# Altium schematic sheet WaveGenerator.SchDoc(WaveGenerator)
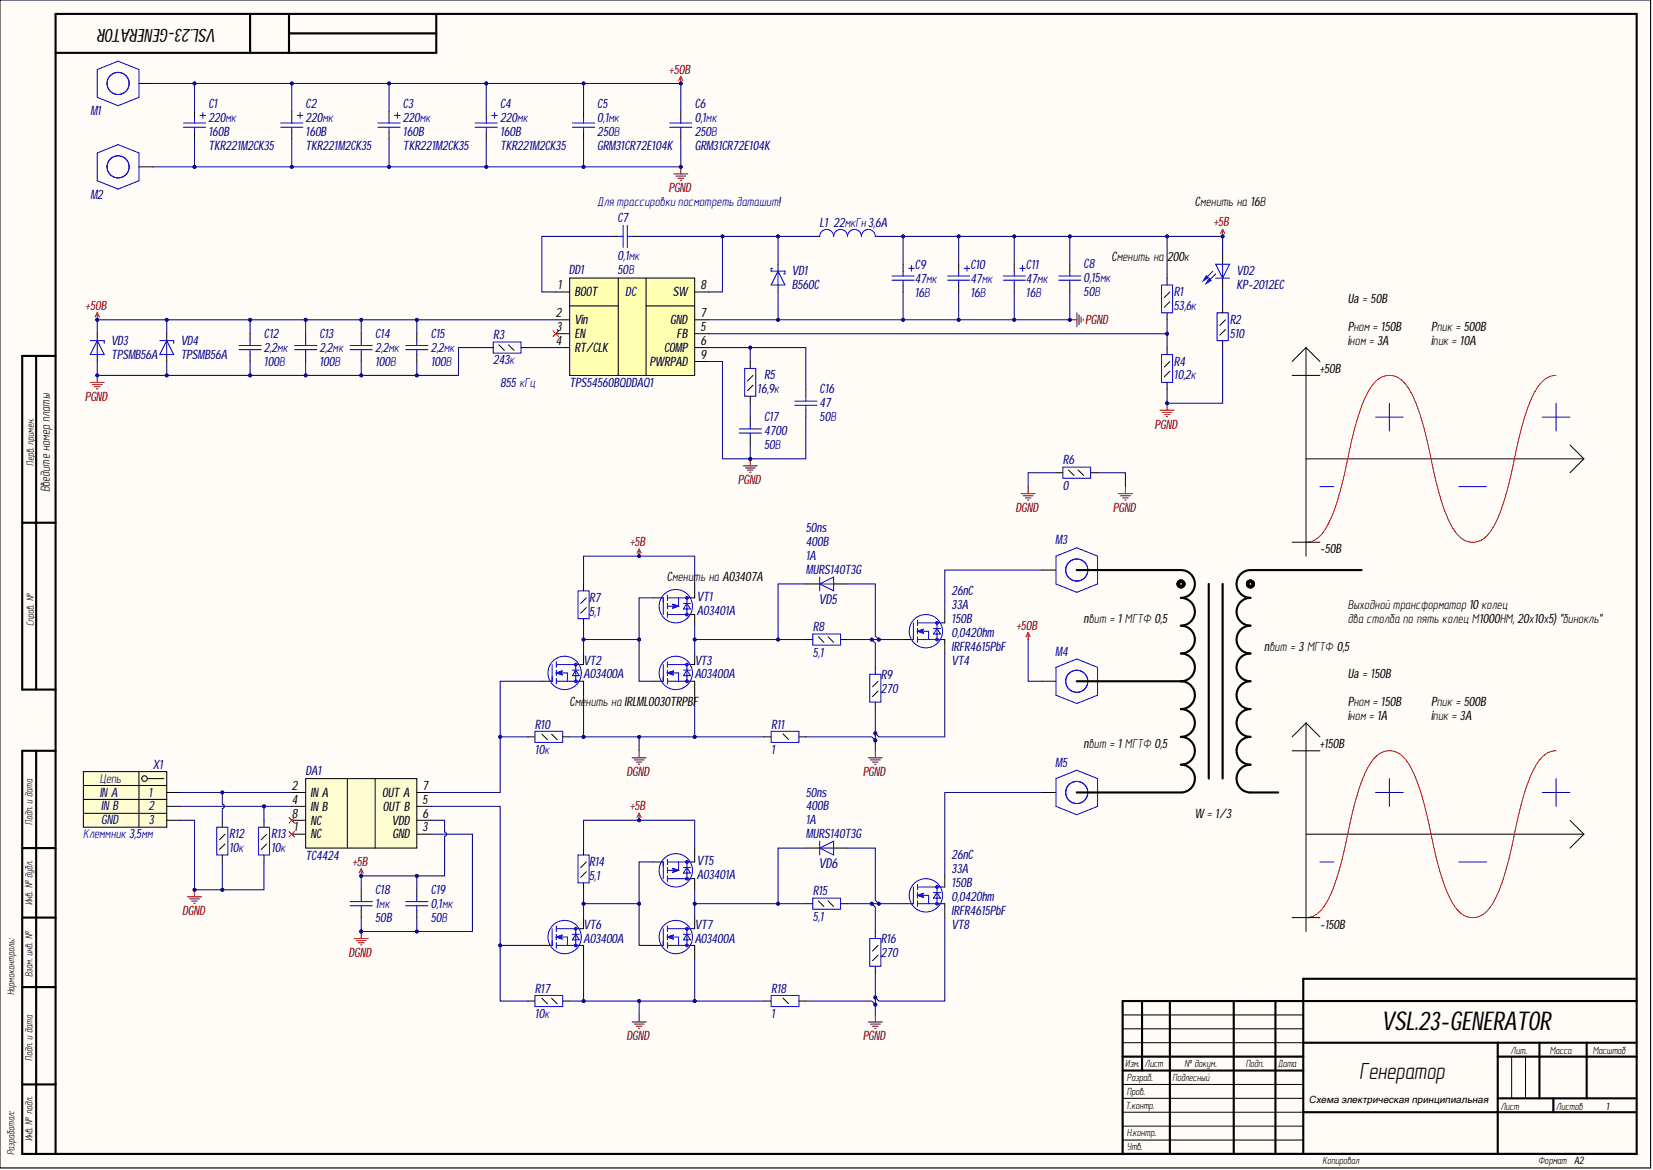
[diagram p0: WaveGenerator.SchDoc]

## Parts
C1  value 220мк  Part Number: TKR221M2CK35  Voltage: 160B  [K50-35(16X35)_V]  (hidden comment: Capacitor_pol)
C2  value 220мк  Part Number: TKR221M2CK35  Voltage: 160B  [K50-35(16X35)_V]  (hidden comment: Capacitor_pol)
C3  value 220мк  Part Number: TKR221M2CK35  Voltage: 160B  [K50-35(16X35)_V]  (hidden comment: Capacitor_pol)
C4  value 220мк  Part Number: TKR221M2CK35  Voltage: 160B  [K50-35(16X35)_V]  (hidden comment: Capacitor_pol)
C5  0,1мк  Voltage: 250В  [C1206]
C6  0,1мк  Part Number: GRM31CR72E104K  Voltage: 250В  [C1206]
C7  0,1мк  [C0402]
C8  0,15мк  Voltage: 50В  [C1812]
C9  value 47мк  Voltage: 16В  [TANTAL CASE C]  (hidden comment: Capacitor_pol)
C10  value 47мк  Voltage: 16В  [TANTAL CASE C]  (hidden comment: Capacitor_pol)
C11  value 47мк  Voltage: 16В  [TANTAL CASE C]  (hidden comment: Capacitor_pol)
C12  2,2мк  Voltage: 100В  [C1812]
C13  2,2мк  Voltage: 100В  [C1812]
C14  2,2мк  Voltage: 100В  [C1812]
C15  2,2мк  Voltage: 100В  [C1812]
C16  47  Voltage: 50В  [C0805]
C17  4700  Voltage: 50В  [C0805]
C18  1мк  Voltage: 50B  [C0805]
C19  0,1мк  Voltage: 50В  [C0805]
DA1  TC4424  [DIP8]
DD1  TPS54560  Part Number: TPS54560BQDDAQ1  [SO Powerpad8]
L1  value 22мкГн  Rated Current: 3,6A  [IND_12.5x12.5]  (hidden comment: Inductor)
M1  SCREW  [SCREW2.5]  (value not drawn)
M2  SCREW  [SCREW2.5]  (value not drawn)
M3  SCREW  [SCREW2.5]  (value not drawn)
M4  SCREW  [SCREW2.5]  (value not drawn)
M5  SCREW  [SCREW2.5]  (value not drawn)
R1  value 53,6к  [R0805]  (hidden comment: Resistor_0,125Вт)
R2  value 510  [R0805]  (hidden comment: Resistor_0,125Вт)
R3  value 243к  [R0805]  (hidden comment: Resistor_0,125Вт)
R4  value 10,2к  [R0805]  (hidden comment: Resistor_0,125Вт)
R5  value 16,9к  [R0805]  (hidden comment: Resistor_0,125Вт)
R6  value 0  [R0805]  (hidden comment: Resistor_0,125Вт)
R7  value 5,1  [R0805]  (hidden comment: Resistor_0,125Вт)
R8  value 5,1  [R0805]  (hidden comment: Resistor_0,125Вт)
R9  value 270  [R0805]  (hidden comment: Resistor_0,125Вт)
R10  value 10к  [R0805]  (hidden comment: Resistor_0,125Вт)
R11  value 1  [R1206]  (hidden comment: Resistor_0,25Вт)
R12  value 10к  [R0805]  (hidden comment: Resistor_0,125Вт)
R13  value 10к  [R0805]  (hidden comment: Resistor_0,125Вт)
R14  value 5,1  [R0805]  (hidden comment: Resistor_0,125Вт)
R15  value 5,1  [R0805]  (hidden comment: Resistor_0,125Вт)
R16  value 270  [R0805]  (hidden comment: Resistor_0,125Вт)
R17  value 10к  [R0805]  (hidden comment: Resistor_0,125Вт)
R18  value 1  [R1206]  (hidden comment: Resistor_0,25Вт)
VD1  Part Number: B560C  [SMC]  (hidden comment: DiodeSchottky)
VD2  Part Number: KP-2012EC  [L_0805]  (hidden comment: LED)
VD3  DiodeZener  [SMB]  (value not drawn)
VD4  DiodeZener  [SMB]  (value not drawn)
VD5  Forward Current: 1A  Part Number: MURS140T3G  [SMB]  (hidden comment: Diode)
VD6  Forward Current: 1A  Part Number: MURS140T3G  [SMB]  (hidden comment: Diode)
VT1  Part Number: AO3401A  [SOT23-3]  (hidden comment: P-MOSFET)
VT2  Part Number: AO3400A  [SOT23-3]  (hidden comment: N-MOSFET)
VT3  Part Number: AO3400A  [SOT23-3]  (hidden comment: N-MOSFET)
VT4  Continuous drain current: 33A  Part Number: IRFR4615PbF  Rds: 0,042Ohm  Total gate charge: 26nC  V drain-source max: 150B  [DPAK]  (hidden comment: N-MOSFET)
VT5  Part Number: AO3401A  [SOT23-3]  (hidden comment: P-MOSFET)
VT6  Part Number: AO3400A  [SOT23-3]  (hidden comment: N-MOSFET)
VT7  Part Number: AO3400A  [SOT23-3]  (hidden comment: N-MOSFET)
VT8  Continuous drain current: 33A  Part Number: IRFR4615PbF  Rds: 0,042Ohm  Total gate charge: 26nC  V drain-source max: 150B  [DPAK]  (hidden comment: N-MOSFET)
X1  Клеммник 3,5мм  Contact_1: IN A  Contact_2: IN B  Contact_3: GND  [TERMINAL-3WAY-3.5MM]

## Nets
+5B: C8.1 C9.1 C10.1 C11.1 C18.2 C19.2 DA1.6(VDD) L1.2 R1.2 R7.2 R14.2 VD2.2 VT1.3 VT5.3
+50B: C1.1 C2.1 C3.1 C4.1 C5.2 C6.2 C12.2 C13.2 C14.2 C15.2 DD1.2(Vin) M1.1 M4.1 VD3.1 VD4.1
DGND: C18.1 C19.1 DA1.3(GND) R6.1 R10.1 R11.1 R12.1 R13.1 R17.1 R18.1 VT2.3 VT3.3 VT6.3 VT7.3 X1.3
PGND: C1.2 C2.2 C3.2 C4.2 C5.1 C6.1 C8.2 C9.2 C10.2 C11.2 C12.1 C13.1 C14.1 C15.1 C16.1 C17.1 DD1.7(GND) DD1.9(PWRPAD) M2.1 R2.1 R3.1 R4.1 R6.2 R9.1 R11.2 R16.1 R18.2 VD1.2 VD3.2 VD4.2 VT4.3 VT8.3
NetC7_1: C7.1 DD1.8(SW) L1.1 VD1.1
NetC7_2: C7.2 DD1.1(BOOT)
NetC16_2: C16.2 DD1.6(COMP) R5.2
NetC17_2: C17.2 R5.1
NetDA1_2: DA1.2(IN_A) R12.2 X1.1
NetDA1_4: DA1.4(IN_B) R13.2 X1.2
NetDA1_5: DA1.5(OUT_B) R17.2 VT6.1
NetDA1_7: DA1.7(OUT_A) R10.2 VT2.1
NetDD1_4: DD1.4(RT/CLK) R3.2
NetDD1_5: DD1.5(FB) R1.1 R4.2
NetM3_1: M3.1 VT4.2
NetM5_1: M5.1 VT8.2
NetR2_2: R2.2 VD2.1
NetR7_1: R7.1 VT1.1 VT2.2 VT3.1
NetR8_1: R8.1 VD5.1 VT1.2 VT3.2
NetR8_2: R8.2 R9.2 VD5.2 VT4.1
NetR14_1: R14.1 VT5.1 VT6.2 VT7.1
NetR15_1: R15.1 VD6.1 VT5.2 VT7.2
NetR15_2: R15.2 R16.2 VD6.2 VT8.1

Not connected: DA1 1(NC) 8(NC); DD1 3(EN)

## Library data of the parts
C1-C4 = cap278: Конденсатор полярный; Manufacture: Jamicon; Part Name: Electrolit; Database Table Name: Capacitors$; ESR: 95мОм; Iac max: 0,5А; Iac rated: 0,9А; Zmax: 38мОм; Component Kind: Standard; id: cap278; Library Name: MOP44.DbLib
C5 = cap179: Конденсатор; Manufacture: Murata; Part Name: Ceramic; Part Number: GRM31CR72E104K; Database Table Name: Capacitors$; TKE: X7R; Component Kind: Standard; id: cap179; Library Name: MOP44.DbLib; Value: 0,1мк
C6 = cap179: Конденсатор; Manufacture: Murata; Part Name: Ceramic; Database Table Name: Capacitors$; TKE: X7R; Component Kind: Standard; id: cap179; Library Name: MOP44.DbLib; Value: 0,1мк
C7 = cap277: Конденсатор; Manufacture: Murata; Part Name: Ceramic; Part Number: GRM155R71H104KE14D; Database Table Name: Capacitors$; tga: 0,10%; TKE: X7R; Voltage: 50В; Component Kind: Standard; id: cap277; Library Name: MOP44.DbLib; Value: 0,1мк
C8 = cap285: Конденсатор; Manufacture: TDK; Part Name: Ceramic; Part Number: C4532C0G1H154J250KA; Database Table Name: Capacitors$; TKE: NP0; Component Kind: Standard; id: cap285; Library Name: MOP44.DbLib; Value: 0,15мк
C9-C11 = cap306: Конденсатор полярный; Manufacture: Китай; Part Name: Tantal; Part Number: TECAP47/16VC10; Database Table Name: Capacitors$; Component Kind: Standard; id: cap306; Library Name: MOP44.DbLib
C12-C15 = cap293: Конденсатор; Manufacture: TDK; Part Name: MLCC; Part Number: C4532X7R2A225M; Database Table Name: Capacitors$; tga: 0,10%; TKE: X7R; Component Kind: Standard; id: cap293; Library Name: MOP44.DbLib; Value: 2,2мк
C16 = cap305: Конденсатор; Manufacture: Murata; Part Name: MLCC; Part Number: GRM2165C1H470JD01D; Database Table Name: Capacitors$; tga: 0,10%; TKE: NP0; Component Kind: Standard; id: cap305; Library Name: MOP44.DbLib; Value: 47
C17 = cap169: Конденсатор; Manufacture: Murata; Part Name: Ceramic; Part Number: GRM216R71H472K; Database Table Name: Capacitors$; TKE: X7R; Component Kind: Standard; id: cap169; Library Name: MOP44.DbLib; Value: 4700
C18 = cap151: Конденсатор; Manufacture: Murata; Part Name: Ceramic; Part Number: GRM21BR71H105K; Database Table Name: Capacitors$; TKE: X7R; Component Kind: Standard; id: cap151; Library Name: MOP44.DbLib; Value: 1мк
C19 = cap160: Конденсатор; Manufacture: Murata; Part Name: Ceramic; Part Number: GRM21BR71H104KA01L; Database Table Name: Capacitors$; TKE: X7R; Component Kind: Standard; id: cap160; Library Name: MOP44.DbLib; Value: 0,1мк
DA1 = driver29: 3A Dual High-Speed Power MOSFET Drivers; Manufacture: Microchip; Part Number: TC4424CPA; Database Table Name: Driver$; Logic High: 2,4В; Logic Low: 0,8B-1,6B; Max Operating Supply Voltage: 18B; Max Supply Current: 2,5mA; Maximum Power Dissipation: 730mW; Min Operating Supply Voltage: 4,5B; “A” OUTPUT: Noninverting; Component Kind: Standard; id: driver29; Library Name: MOP44.DbLib; Maximum output current: 3A; Part Name: 3A Dual High-Speed Power MOSFET Drivers; “B” OUTPUT: Noninverting
DD1 = pwr127: 5-A, Wide Input Range, Step-Down Converter; Manufacturer: Texas Instruments; Part Name: Step Down DC-DC Converter with Eco-mode; Current: 5A; Database Table Name: PowerTransducer$; Frequency: 100 kHz-25 MHz; Input Voltage: 4,5-60В; Output Voltage: 0,8B-58,8B; Component Kind: Standard; id: pwr127; Library Name: MOP44.DbLib; Type: Impulse
L1 = ind79: EMI Suppression Filter; Manufacture: Epcos; Part Name: SMD Power Inductors; Part Number: B82477G4223M000; Database Table Name: Inductor$; Resistance: 0,038Ohm; Saturation Current: 4,25A; Component Kind: Standard; id: ind79; Library Name: MOP44.DbLib
M1-M5 = connect88: Part Name: Винт М2.5 с заземлением; Database Table Name: Connector$; Component Kind: Standard; id: connect88; Library Name: MOP44.DbLib
R1 = res1234: Резистор; Manufacture: Yageo; Part Number: RC0805FR-0753K6L; Accuracy: 0,01; Database Table Name: Resistor$; Component Kind: Standard; id: res1234; Library Name: MOP44.DbLib; Part Name: Resistor; Power: 0,125Вт
R2 = res68: Резистор; Manufacture: Yageo; Part Number: RC0805FR-07510RL; Accuracy: 0,01; Database Table Name: Resistor$; Component Kind: Standard; id: res68; Library Name: MOP44.DbLib; Part Name: Resistor; Power: 0,125Вт
R3 = res1189: Резистор; Manufacture: Yageo; Part Number: RC0805FR-07243KL; Accuracy: 0,01; Database Table Name: Resistor$; Component Kind: Standard; id: res1189; Library Name: MOP44.DbLib; Part Name: Resistor; Power: 0,125Вт
R4 = res1230: Резистор; Manufacture: Yageo; Part Number: RC0805FR-0710K2L; Accuracy: 0,01; Database Table Name: Resistor$; Component Kind: Standard; id: res1230; Library Name: MOP44.DbLib; Part Name: Resistor; Power: 0,125Вт
R5 = res1186: Резистор; Manufacture: Yageo; Part Number: RC0805FR-0716K9L; Accuracy: 0,01; Database Table Name: Resistor$; Component Kind: Standard; id: res1186; Library Name: MOP44.DbLib; Part Name: Resistor; Power: 0,125Вт
R6 = res0: Резистор; Manufacture: Yageo; Part Number: RC0805FR-070RL; Accuracy: 0,01; Database Table Name: Resistor$; Component Kind: Standard; id: res0; Library Name: MOP44.DbLib; Part Name: Resistor; Power: 0,125Вт
R7 R8 R14 R15 = res18: Резистор; Manufacture: Yageo; Part Number: RC0805FR-075R1L; Accuracy: 0,01; Database Table Name: Resistor$; Component Kind: Standard; id: res18; Library Name: MOP44.DbLib; Part Name: Resistor; Power: 0,125Вт
R9 R16 = res60: Резистор; Manufacture: Yageo; Part Number: RC0805FR-07270RL; Accuracy: 0,01; Database Table Name: Resistor$; Component Kind: Standard; id: res60; Library Name: MOP44.DbLib; Part Name: Resistor; Power: 0,125Вт
R10 R12 R13 R17 = res101: Резистор; Manufacture: Yageo; Part Number: RC0805FR-0710KL; Accuracy: 0,01; Database Table Name: Resistor$; Component Kind: Standard; id: res101; Library Name: MOP44.DbLib; Part Name: Resistor; Power: 0,125Вт
R11 R18 = res584: Резистор; Manufacture: Yageo; Part Number: RC1206FR-071RL; Accuracy: 0,01; Database Table Name: Resistor$; Power: 0,25Вт; Component Kind: Standard; id: res584; Library Name: MOP44.DbLib; Part Name: Resistor
VD1 = diode32: Диод Шоттки; Manufacture: Diodes Incorporated; Part Name: SCHOTTKY BARRIER RECTIFIER; Breakdown Voltage: 60B; Capacitance: 300pF; Database Table Name: Diode$; Forward Current: 5A; Forward Voltage: 0,7B; Leakage current: 20mA; Number of Channels: 1; Component Kind: Standard; id: diode32; Library Name: MOP44.DbLib; Max Reverse Voltage: 60B; Temp MAX: +150; Temp MIN: -55
VD2 = diode5: Светодиод; Database Table Name: Diode$; Component Kind: Standard; id: diode5; Library Name: MOP44.DbLib; Part Name: LED
VD3 VD4 = TPSMB56A: Cтабилитрон (лавинный выпрямительный диод) односторонний; Manufacture: Littelfuse; Part Name: TVS diode Unidirectional; Breakdown Voltage: 58,8B; Clamping voltage: 77B; Database Table Name: TVSdiode$; id: tvs285; Leakage Current: 8uA; Number of diodes: 1; Peak Pulse Power: 600W; Stand-off Voltage: 56B; Component Kind: Standard; Library Name: MOP44.DbLib; Part Number: TPSMB56A
VD5 VD6 = diode33: Диод; Manufacture: ON Semiconductor; Part Name: Ultrafast Power Rectifiers; Breakdown Voltage: 400B; Database Table Name: Diode$; Forward Voltage: 1,25B; Reverse Recovery time: 50ns; Component Kind: Standard; id: diode33; Library Name: MOP44.DbLib; Max Reverse Voltage: 400B
VT1 VT5 = mosfert27: N-MOSFET; Manufacturer: Alpha&Omega; Continuous drain current: (-4)A; Database Table Name: MOSFETTransistor$; Gate-to-source voltage: (+-)12B; Power dissipation: 1,4W; Pulsed drain current: (-27)A; Rds: 0,06Ohm; Structure: p-channel; Total gate charge: 14nC; V drain-source max: (-30B); Component Kind: Standard; id: mosfert27; Library Name: MOP44.DbLib
VT2 VT3 VT6 VT7 = mosfert26: Manufacturer: Alpha&Omega; Continuous drain current: 5,7A; Database Table Name: MOSFETTransistor$; Gate-to-source voltage: (+-)12B; Power dissipation: 1,4W; Pulsed drain current: 30A; Rds: 0,032Ohm; Structure: n-channel; Total gate charge: 7nC; V drain-source max: 30B; Component Kind: Standard; Description: N-MOSFET; id: mosfert26; Library Name: MOP44.DbLib
VT4 VT8 = mosfert20: Manufacturer: International Rectifier; Database Table Name: MOSFETTransistor$; Gate-to-source voltage: (+-)20B; Power dissipation: 144W; Pulsed drain current: 140A; Structure: n-channel; Component Kind: Standard; Description: N-MOSFET; id: mosfert20; Library Name: MOP44.DbLib
X1 = connect35: Разъем - 3 конт. клеммник; Part Name: TERMINAL BLOCK 3 way; Database Table Name: Connector$; Etch: 3.5mm; Component Kind: Standard; id: connect35; Library Name: MOP44.DbLib
